# Altium schematic sheet suns_wifi_egse_esp8266.SchDoc
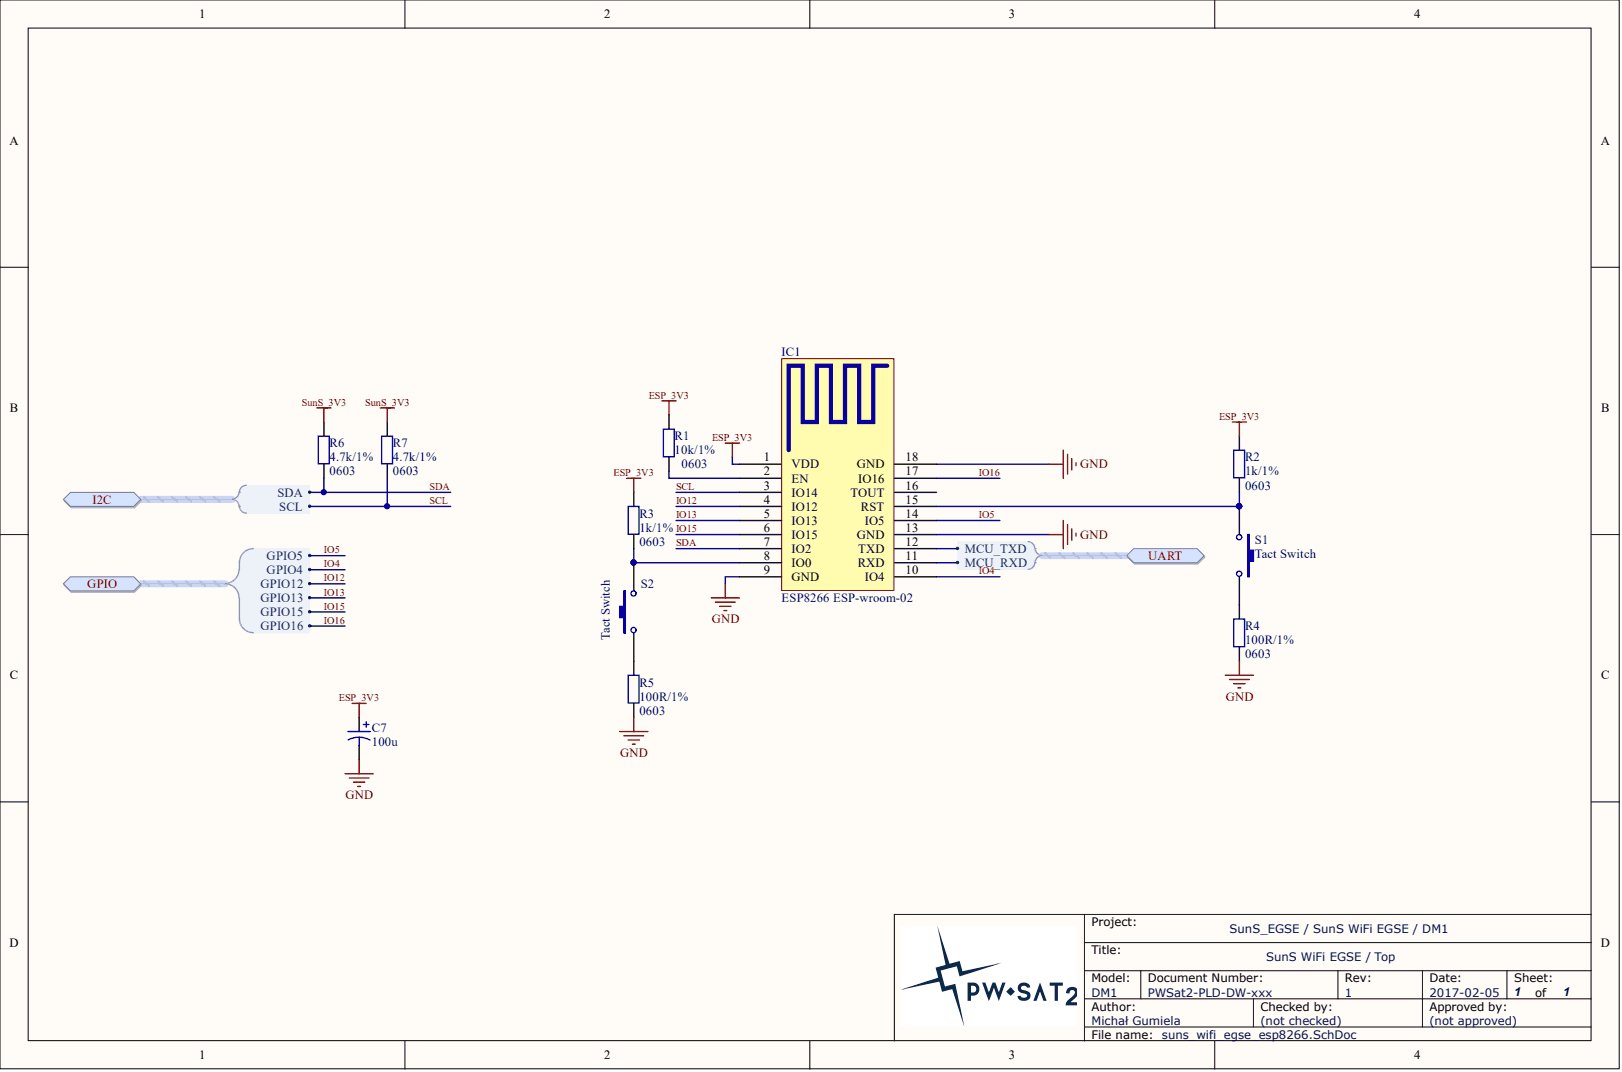
[diagram p0: suns_wifi_egse_esp8266.SchDoc]

## Parts
C7  100u  [CAP_ELEC_6.3x4.2]
IC1  ESP8266 ESP-wroom-02  [ESP8266 ESP-wroom-02]
R1  10k/1%  Package: 0603  [SMD_Resistor_0603]
R2  1k/1%  Package: 0603  [SMD_Resistor_0603]
R3  1k/1%  Package: 0603  [SMD_Resistor_0603]
R4  100R/1%  Package: 0603  [SMD_Resistor_0603]
R5  100R/1%  Package: 0603  [SMD_Resistor_0603]
R6  4.7k/1%  Package: 0603  [SMD_Resistor_0603]
R7  4.7k/1%  Package: 0603  [SMD_Resistor_0603]
S1  Tact Switch  [Tact Switch 3x6]
S2  Tact Switch  [Tact Switch 3x6]

## Ports
GPIO, GPIO.GPIO4, GPIO.GPIO5, GPIO.GPIO12, GPIO.GPIO13, GPIO.GPIO15, GPIO.GPIO16, I2C, I2C.SCL, I2C.SDA, UART, UART.MCU_RXD, UART.MCU_TXD

## Nets
ESP_3V3: C7.1 IC1.1(VDD) R1.2 R2.2 R3.2
GND: C7.2 IC1.9(GND) IC1.13(GND) IC1.18(GND) R4.1 R5.1
SUNS_3V3: R6.1 R7.1
IO4: IC1.10(IO4) ; port GPIO
IO5: IC1.14(IO5) ; port GPIO
IO12: IC1.4(IO12) ; port GPIO
IO13: IC1.5(IO13) ; port GPIO
IO15: IC1.6(IO15) ; port GPIO
IO16: IC1.17(IO16) ; port GPIO
SCL: IC1.3(IO14) R7.2 ; port I2C
SDA: IC1.7(IO2) R6.2 ; port I2C
NetIC1_2: IC1.2(EN) R1.1
NetIC1_8: IC1.8(IO0) R3.1 S2.2
NetIC1_11: IC1.11(RXD) ; port UART
NetIC1_12: IC1.12(TXD) ; port UART
NetIC1_15: IC1.15(RST) R2.1 S1.2
NetR4_2: R4.2 S1.1
NetR5_2: R5.2 S2.1

Not connected: IC1 16(TOUT)

## Library data of the parts
C7 = Cap Pol: Polarized Capacitor; Component Kind: Standard; ComponentLink1Description: Datasheet; value: 100u; Library Name: WSN.IntLib
R1-R5 = CRCW06031K00FKxxxx: 1k/1% Vishay CRCW 0603 Resistor. 100mW, 100ppm, 75V.; Component Kind: Standard; Library Name: resistors.IntLib; Manufacturer: Vishay; ManufacturerPartNumber: CRCW06031K00FKxxxx
  R1: Value: 10k/1%
  R2 R3: Value: 1k/1%
  R4 R5: Value: 100R/1%
R6 R7 = CRCW06034K70FKxxxx: 4.7k/1% Vishay CRCW 0603 Resistor. 100mW, 100ppm, 75V.; Component Kind: Standard; Library Name: resistors.IntLib; ManufactuerPartNumber: CRCW06034K70FKxxxx; Manufacturer: Vishay; Value: 4.7k/1%
S1 S2 = TactSwitch: Component Kind: Standard; Library Name: switches.IntLib; Supplier 1: Farnell
IC1 = ESP8266 ESP-wroom-02: Component Kind: Standard; Description: ESP8266 ESP-wroom-02; Library Name: microcircuits.IntLib
